# Altium schematic sheet Inhibits.SchDoc
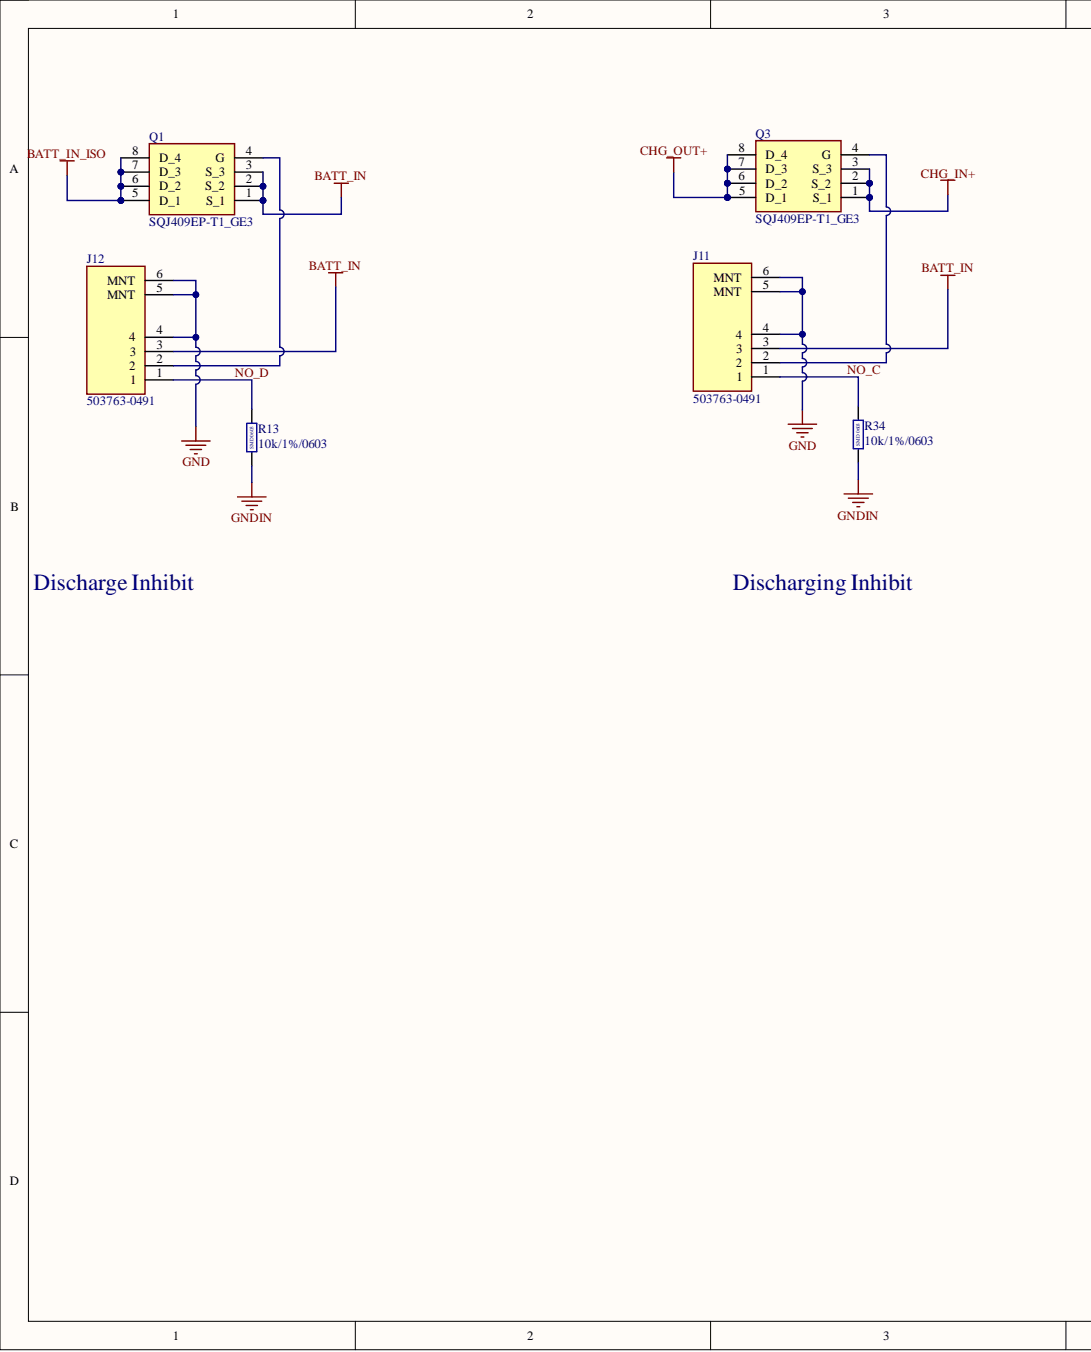
[diagram p0: Inhibits.SchDoc, left]
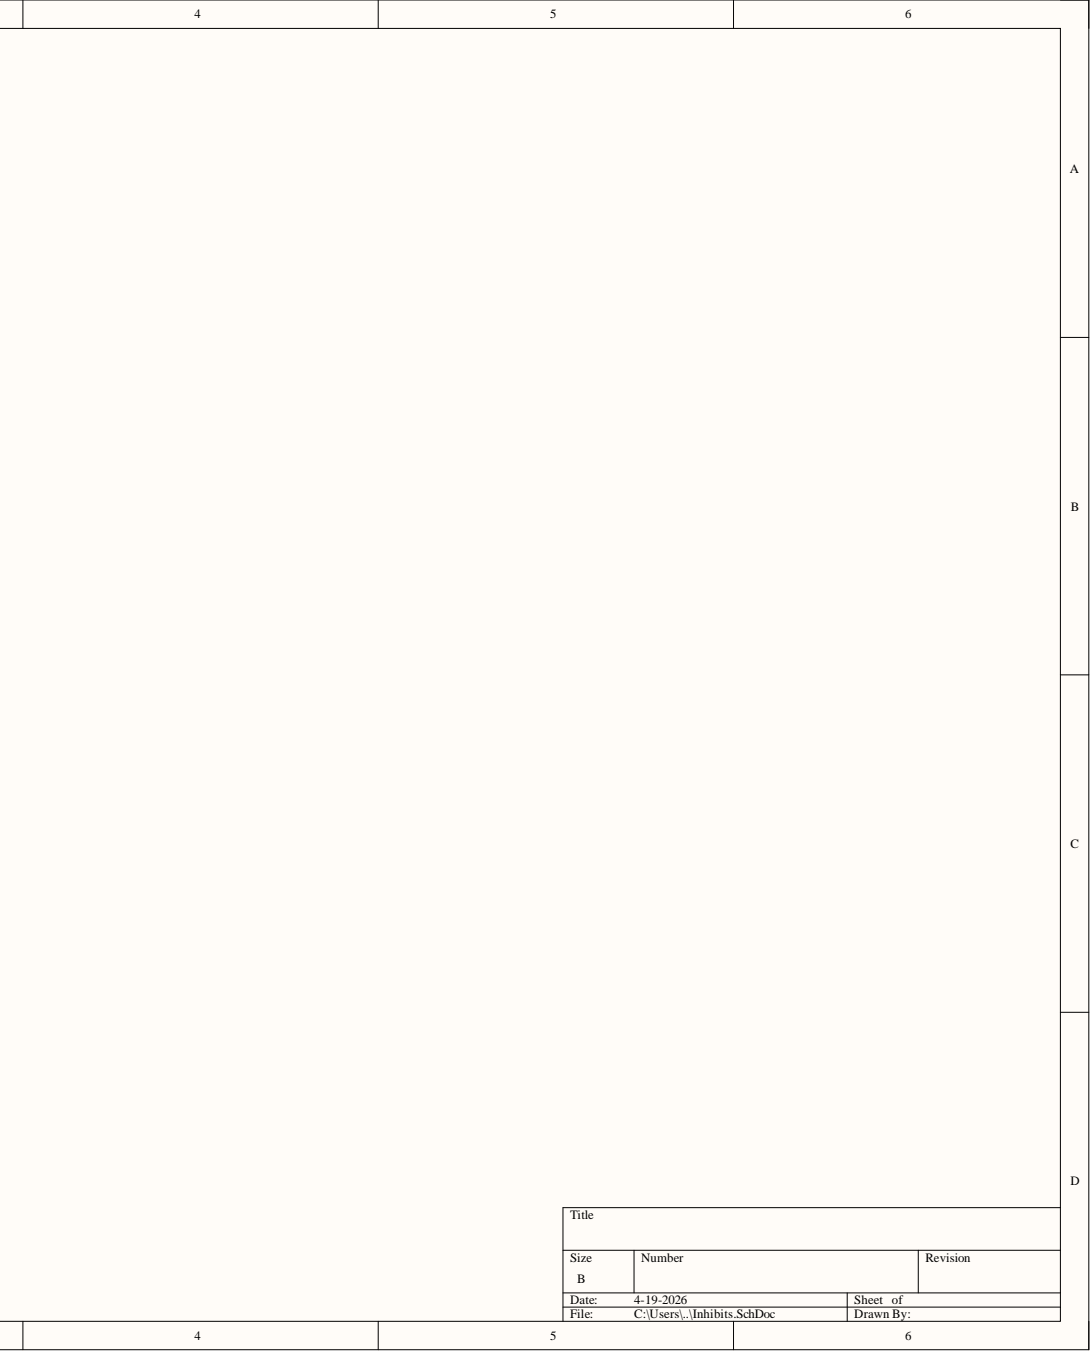
[diagram p1: Inhibits.SchDoc, right]

## Parts
J11  503763-0491  [5037630491]
J12  503763-0491  [5037630491]
Q1  SQJ409EP-T1_GE3  [SQJ211ELPT1_GE3]
Q3  SQJ409EP-T1_GE3  [SQJ211ELPT1_GE3]
R13  10k/1%/0603  [RES_0603]
R34  10k/1%/0603  [RES_0603]

## Nets
BATT_IN: J11.3 J12.3 Q1.1(S_1) Q1.2(S_2) Q1.3(S_3)
BATT_IN_ISO: Q1.5(D_1) Q1.6(D_2) Q1.7(D_3) Q1.8(D_4)
CHG_IN+: Q3.1(S_1) Q3.2(S_2) Q3.3(S_3)
CHG_OUT+: Q3.5(D_1) Q3.6(D_2) Q3.7(D_3) Q3.8(D_4)
GND: J11.4 J11.5(MNT) J11.6(MNT) J12.4 J12.5(MNT) J12.6(MNT)
GNDIN: R13.1 R34.1
NO_C: J11.1 R34.2
NO_D: J12.1 R13.2
NetJ11_2: J11.2 Q3.4(G)
NetJ12_2: J12.2 Q1.4(G)

## Library data of the parts
J11 J12 = 503763-0491: Connector; Manufacturer_Name: Molex; Component Kind: Standard; Datasheet Link: https://www.molex.com/webdocs/datasheets/pdf/en-us/5037630491_PCB_HEADERS.pdf; Height: 1mm; Manufacturer_Part_Number: 503763-0491; Mouser Part Number: 538-503763-0491; Mouser Price/Stock: https://www.mouser.co.uk/ProductDetail/Molex/503763-0491?qs=zwvsCB%2Fxu0cTZVAek%2FYVrQ%3D%3D; Library Name: STeRG-Passives-Standard.SchLib
Q1 Q3 = SQJ409EP-T1_GE3: MOSFET (P-Channel); Manufacturer_Name: Vishay; Arrow Part Number: SQJ409EP-T1_GE3; Arrow Price/Stock: https://www.arrow.com/en/products/sqj409ep-t1-ge3/vishay?utm_currency=USD&region=europe; Component Kind: Standard; Datasheet Link: https://www.vishay.com/docs/77707/sqj409ep.pdf; Height: 1.267mm; Library Name: SamacSys.SchLib; Manufacturer_Part_Number: SQJ409EP-T1_GE3; Mouser Part Number: 78-SQJ409EP-T1_GE3; Mouser Price/Stock: https://www.mouser.co.uk/ProductDetail/Vishay-Semiconductors/SQJ409EP-T1_GE3?qs=5aG0NVq1C4wKikYg4x7ZRQ%3D%3D
R13 R34 = Resistor-SMD-0603: CRCW060310K0FKEA; Component Kind: Standard; Library Name: STeRG-Passives-Standard.SchLib
